# Altium schematic sheet main.SchDoc(main)
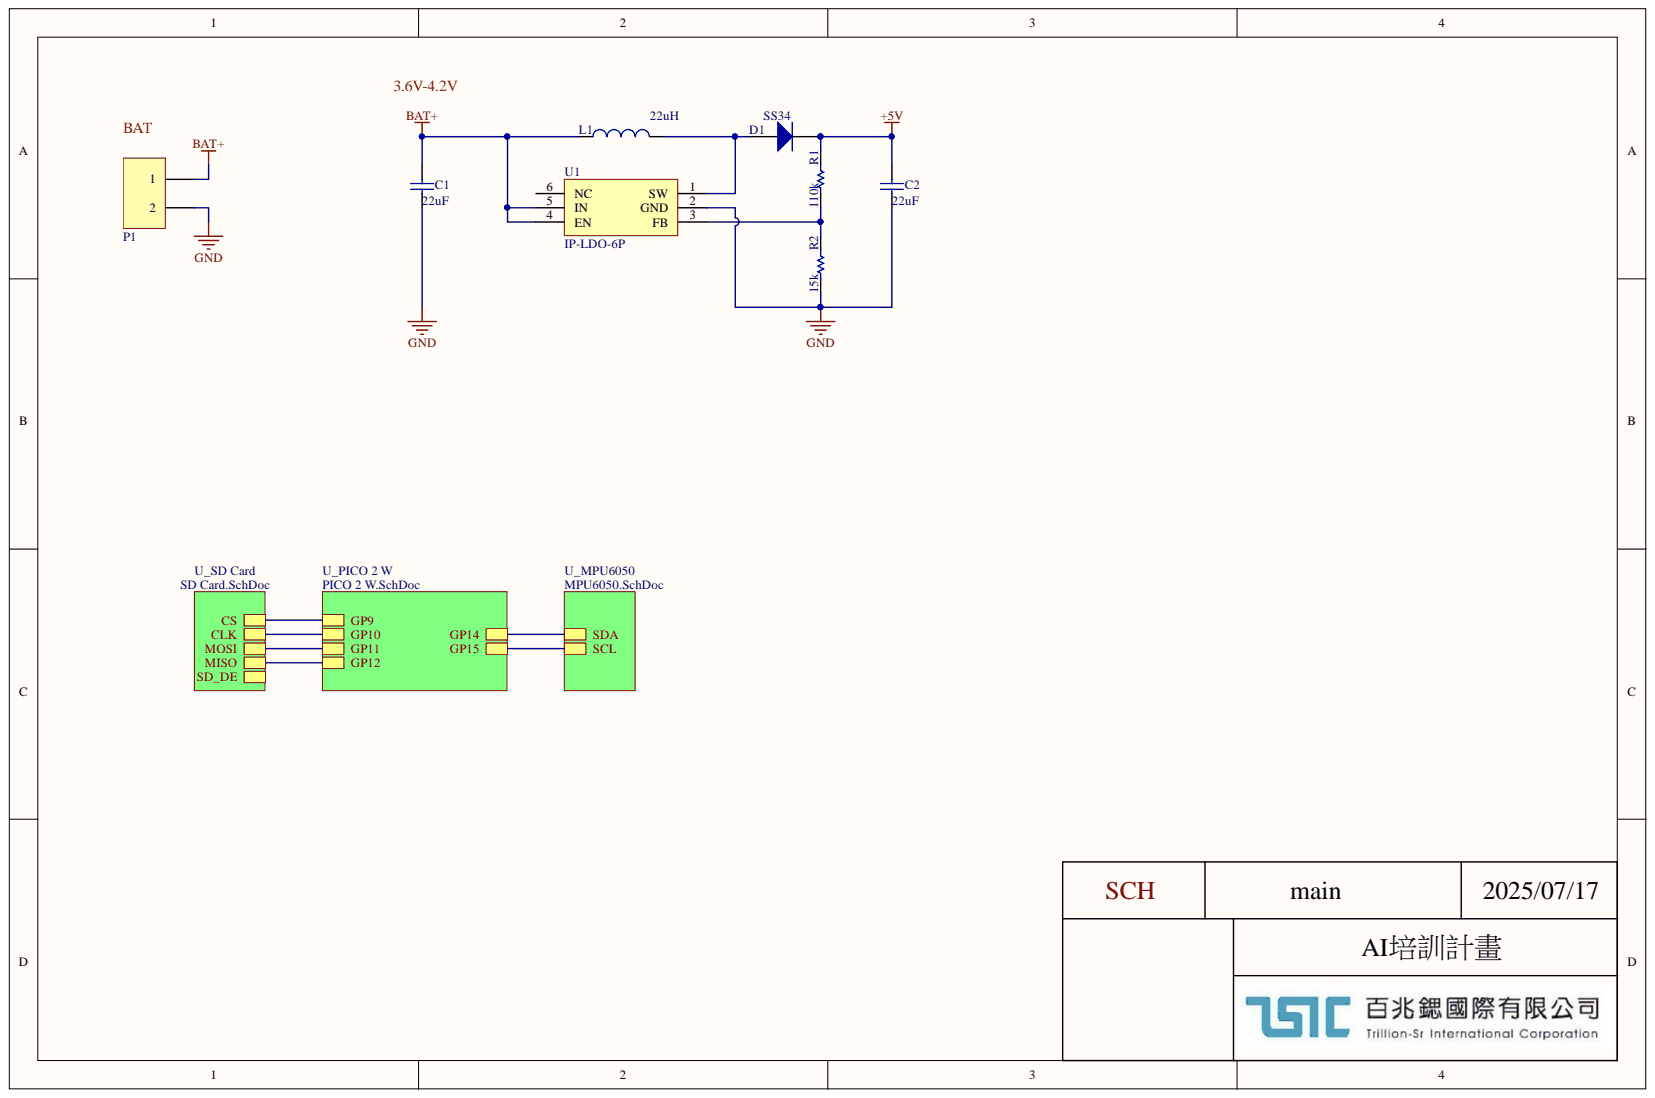
[diagram p0: main.SchDoc]

## Parts
C1  22uF  [C0603]
C2  22uF  [C0603]
D1  SS34  [P-DO-214AC]
L1  22uH  [P-INDUCTOR-7.1*6.5]
P1  header  [C-2.54-2P-XH]  (value not drawn)
R1  110k  [R0603]
R2  15k  [R0603]
U1  IP-LDO-6P  [P-SOT-23-6]

## Nets
+5V: C2.2 D1.1 R1.2
BAT+: C1.2 L1.1 P1.1 U1.4(EN) U1.5(IN)
GND: C1.1 C2.1 P1.2 R2.1 U1.2(GND)
NetD1_2: D1.2 L1.2 U1.1(SW)
NetR1_1: R1.1 R2.2 U1.3(FB)
NetU1_6: U1.6(NC)
GP9:
GP10:
GP11:
GP12:
SCL:
SD_DE:
SDA:

## Library data of the parts
C1 C2 = Cap: Component Kind: Standard; Value: 22uF; Library Name: TSIC_LIB25_V2.IntLib
  C1: Description: CAP
  C2: Description: Cap
D1 = Diode: Component Kind: Standard; Description: Diode; Library Name: TSIC_LIB25_V2.IntLib
L1 = Inductor: Component Kind: Standard; Description: Inductor; Value: 22uH; Library Name: TSIC_LIB25_V2.IntLib
P1 = C-2P: Value: Pitch: ?mm , 2*8P ,??male; Component Kind: Standard; Library Name: TSIC_LIB25_V2.IntLib
R1 R2 = RES: Resistor; Component Kind: Standard; Library Name: TSIC_LIB25_V2.IntLib
  R1: Value: 110k
  R2: Value: 15k
U1 = IP-LDO-6P: Component Kind: Standard; Library Name: chicken_sch.SchLib
